# Altium schematic sheet power.SchDoc(power)
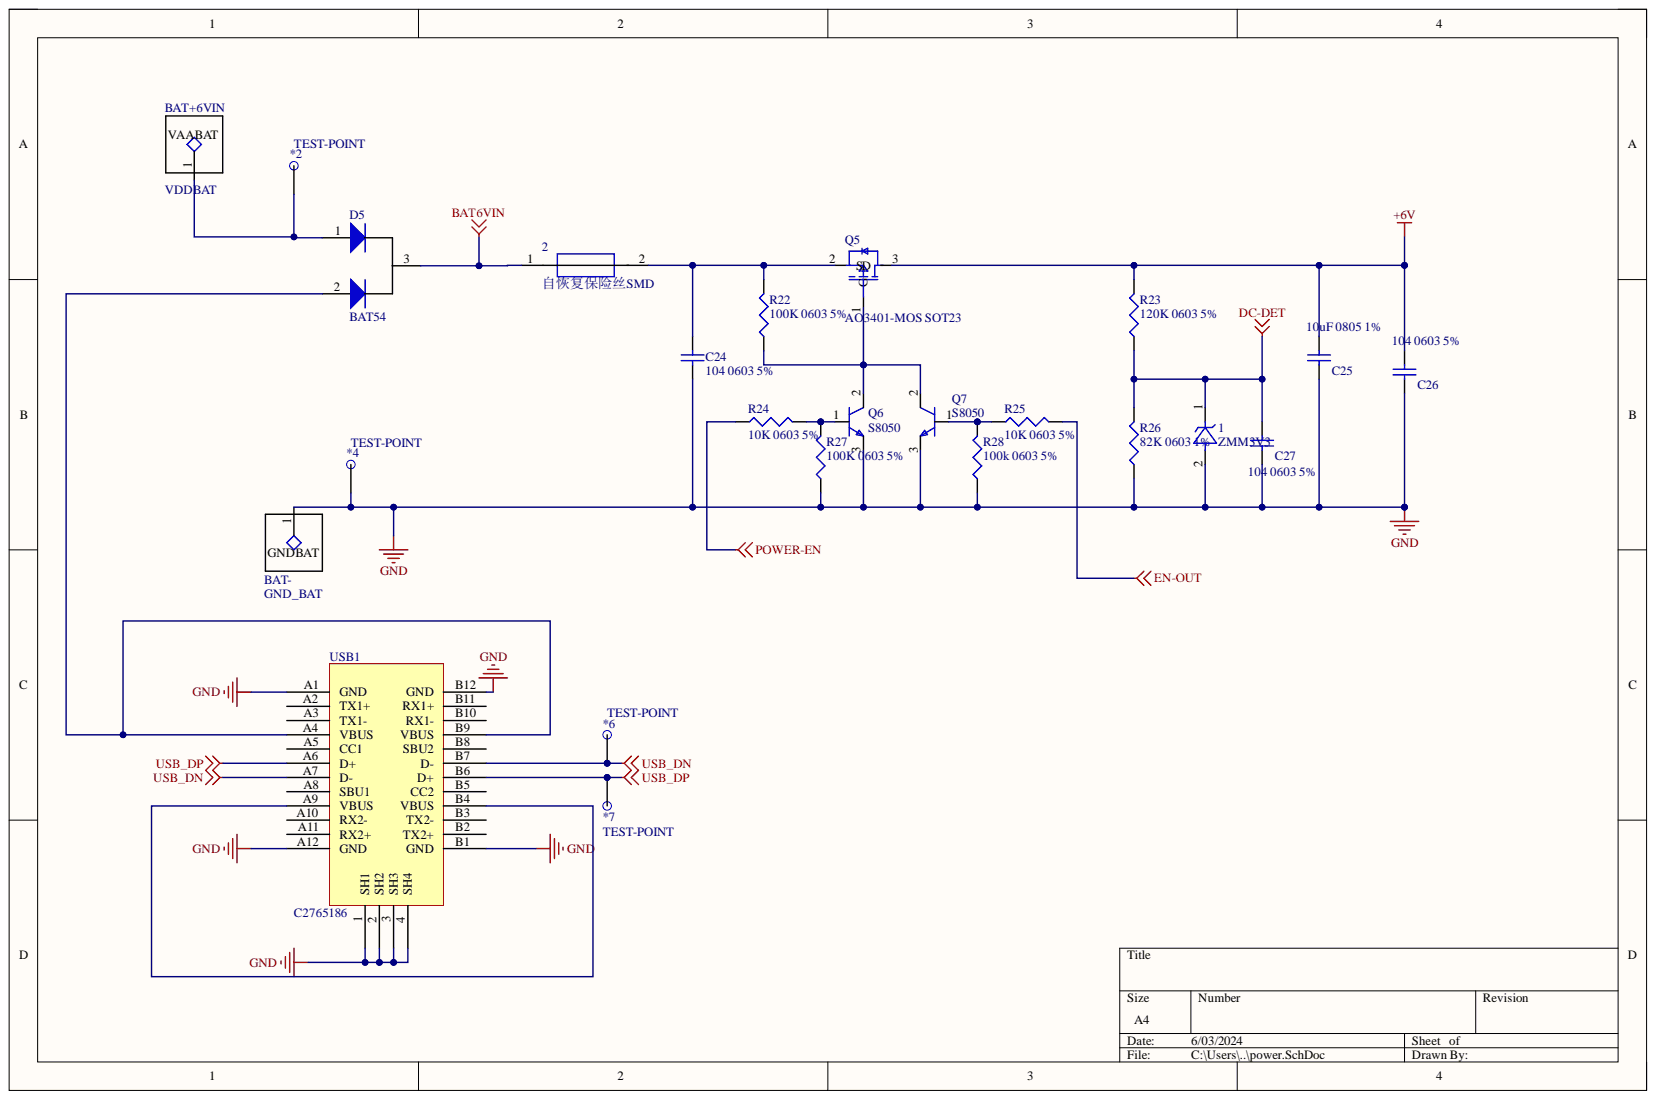
[diagram p0: power.SchDoc]

## Parts
1  ZMM3V3  [LL34]
2  自恢复保险丝SMD  [2920 SMD 自恢复保险丝]
*2  TEST-POINT  [tp]
*4  TEST-POINT  [tp]
*6  TEST-POINT  [tp]
*7  TEST-POINT  [tp]
BAT+6VIN  VDDBAT  [VAABAT]
BAT-  GND_BAT  [GNDBAT]
C24  104 0603 5%  封装: 0603  [CAP0603_3D]
C25  10uF 0805 1%  [CAP0805-3D]
C26  104 0603 5%  封装: 0603  [CAP0603_3D]
C27  104 0603 5%  封装: 0603  [CAP0603_3D]
D5  BAT54  [SOT23-1-0.8]
Q5  AO3401-MOS SOT23  [SOT23-1-0.8]
Q6  S8050  [SOT23]
Q7  S8050  [SOT23]
R22  100K 0603 5%  [RES0603_3D]
R23  120K 0603 5%  [RES0603_3D]
R24  10K 0603 5%  [RES0603_3D]
R25  10K 0603 5%  [RES0603_3D]
R26  82K 0603 1%  [RES0603_3D]
R27  100K 0603 5%  [RES0603_3D]
R28  100k 0603 5%  [RES0603_3D]
USB1  C2765186  [TYPE-C]

## Nets
+6V: C25.1 C26.2 Q5.3 R23.2
BAT6VIN: 2.1 D5.3
DC-DET: 1.1 C27.2 R23.1 R26.2
EN-OUT: R25.2
GND: 1.2 *4.1 BAT-.1 C24.2 C25.2 C26.1 C27.1 Q6.3 Q7.3 R26.1 R27.1 R28.1 USB1.1(SH1) USB1.2(SH2) USB1.3(SH3) USB1.4(SH4) USB1.A1(GND) USB1.A12(GND) USB1.B1(GND) USB1.B12(GND)
POWER-EN: R24.1
USB_DN: *6.1 USB1.A7(D-) USB1.B7(D-)
USB_DP: *7.1 USB1.A6(D+) USB1.B6(D+)
Net2_2: 2.2 C24.1 Q5.2 R22.2
Net*2_1: *2.1 BAT+6VIN.1 D5.1
NetD5_2: D5.2 USB1.A4(VBUS) USB1.B9(VBUS)
NetQ5_1: Q5.1 Q6.2 Q7.2 R22.1
NetQ6_1: Q6.1 R24.2 R27.2
NetQ7_1: Q7.1 R25.1 R28.2
NetUSB1_A9: USB1.A9(VBUS) USB1.B4(VBUS)

Not connected: USB1 A2(TX1+) A3(TX1-) A5(CC1) A8(SBU1) A10(RX2-) A11(RX2+) B2(TX2+) B3(TX2-) B5(CC2) B8(SBU2) B10(RX1-) B11(RX1+)

## Library data of the parts
C24 C26 C27 = CAP_SMT: 贴片多层陶瓷电容; 容值误差: 10%; 耐压: 50V; Component Kind: Standard; Library Name: hwt.SchLib
C25 = CAP_SMT: 贴片多层陶瓷电容; 容值误差: 10%; 封装: 0603; 耐压: 50V; Component Kind: Standard; Library Name: hwt.SchLib
D5 = 复合二极管SOT-23: Component Kind: Standard; Library Name: hwt.SchLib
R22-R25 R27 R28 = RES_SMT: 精度误差: 1%; Component Kind: Standard; Library Name: hwt.SchLib
R26 = RES_SMT: Component Kind: Standard; 精度误差: 1%; Library Name: hwt.SchLib
USB1 = TYPE-C: Component Kind: Standard; Library Name: 基于STM32的DDS信号源设计实例.SCHLIB
1 = ZMM3V3: Component Kind: Standard; Library Name: hhh.SCHLIB
2 = 自恢复保险丝SMD: Component Kind: Standard; Library Name: hwt.SchLib
*2 *4 *6 *7 = TEST-POINT: Component Kind: Standard; Library Name: hwt.SchLib
BAT+6VIN = VDDBAT: Component Kind: Standard; Library Name: hwt.SchLib
BAT- = GND_BAT: Component Kind: Standard; Library Name: hwt.SchLib
Q5 = AO3401-MOS SOT23: Component Kind: Standard; Library Name: hhh.SCHLIB
Q6 Q7 = S8050: Component Kind: Standard; Library Name: hhh.SCHLIB
